# Altium schematic sheet 08_ONB_COMPASS.SchDoc(08_ONB_COMPASS)
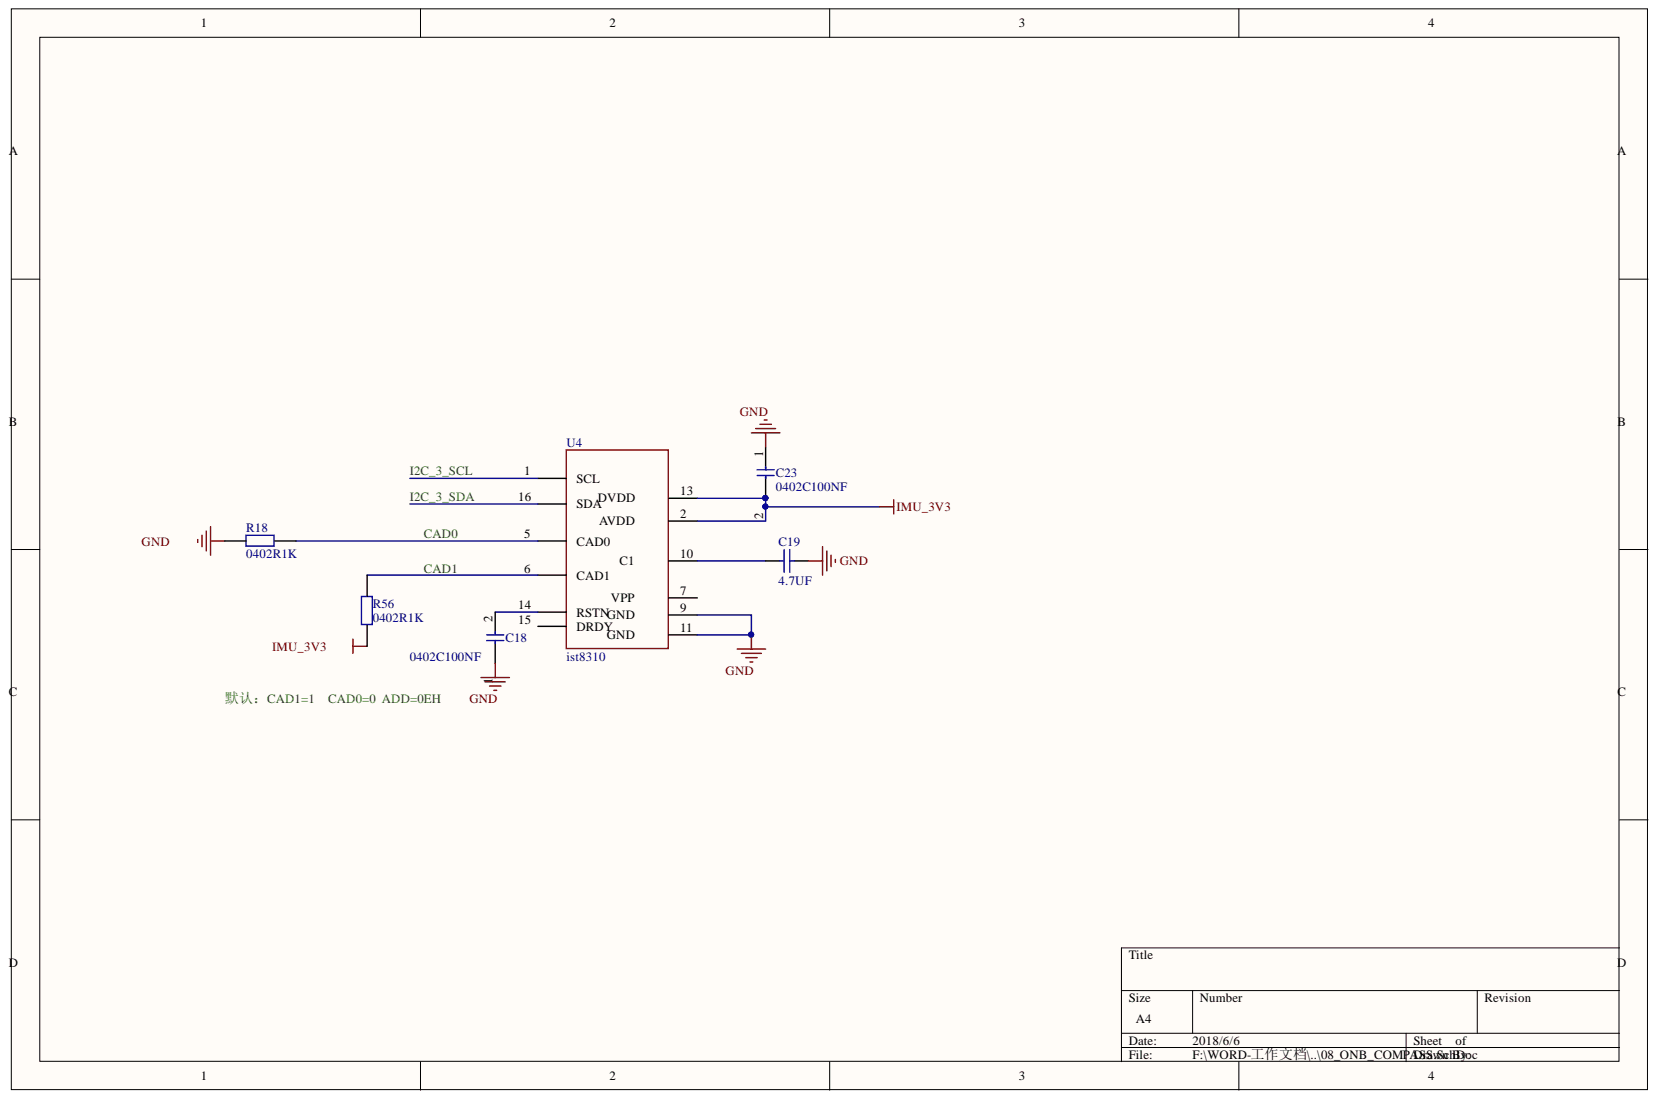
[diagram p0: 08_ONB_COMPASS.SchDoc]

## Parts
C18  0402C100NF  [0402C]
C19  4.7UF  [0805CAP]
C23  0402C100NF  [0402C]
R18  0402R1K  [0402R]
R56  0402R1K  [0402R]
U4  ist8310  [LGA16_4X4]

## Nets
GND: C18.1 C19.2 C23.1 R18.2 U4.9 U4.11
IMU_3V3: C23.2 R56.1 U4.2 U4.13
CAD0: R18.1 U4.5(CAD0)
CAD1: R56.2 U4.6(CAD1)
I2C_3_SCL: U4.1(SCL)
I2C_3_SDA: U4.16(SDA)
NetC18_2: C18.2 U4.14(RSTN)
NetC19_1: C19.1 U4.10
默认：CAD1=1    CAD0=0  ADD=0EH:

Not connected: U4 7 15(DRDY)

## Library data of the parts
C18 C23 = 0402C100NF: 50V 5%; Component Kind: Standard; Library Name: CUAV.SchLib
C19 = Cap: Capacitor; Component Kind: Standard; Library Name: Miscellaneous Devices.IntLib; Signal Integrity: Cap; Simulation: CAP
R18 R56 = 0402R1K: 0402R1K 1%; Component Kind: Standard; Library Name: CUAV.SchLib
U4 = ist8310: Component Kind: Standard; Library Name: CUAV.SchLib
